# Altium schematic sheet RFB-D.SchDoc(RFB-D)
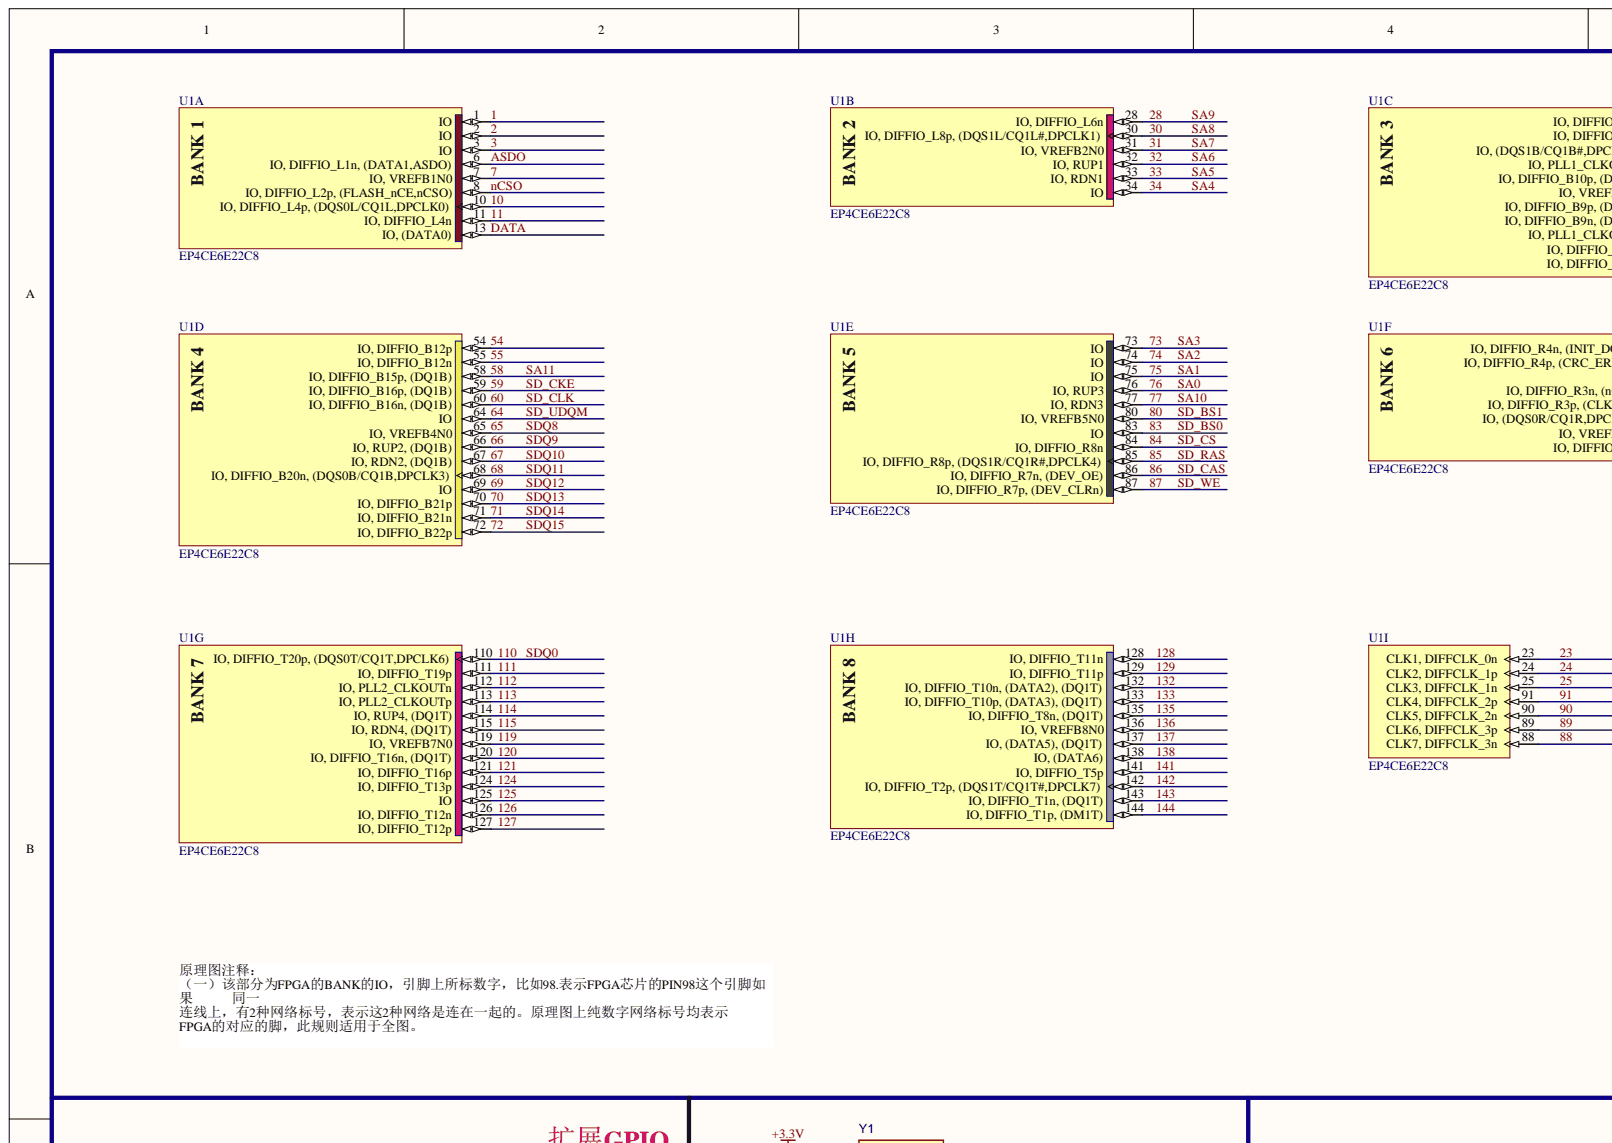
[diagram p0: RFB-D.SchDoc, top left]
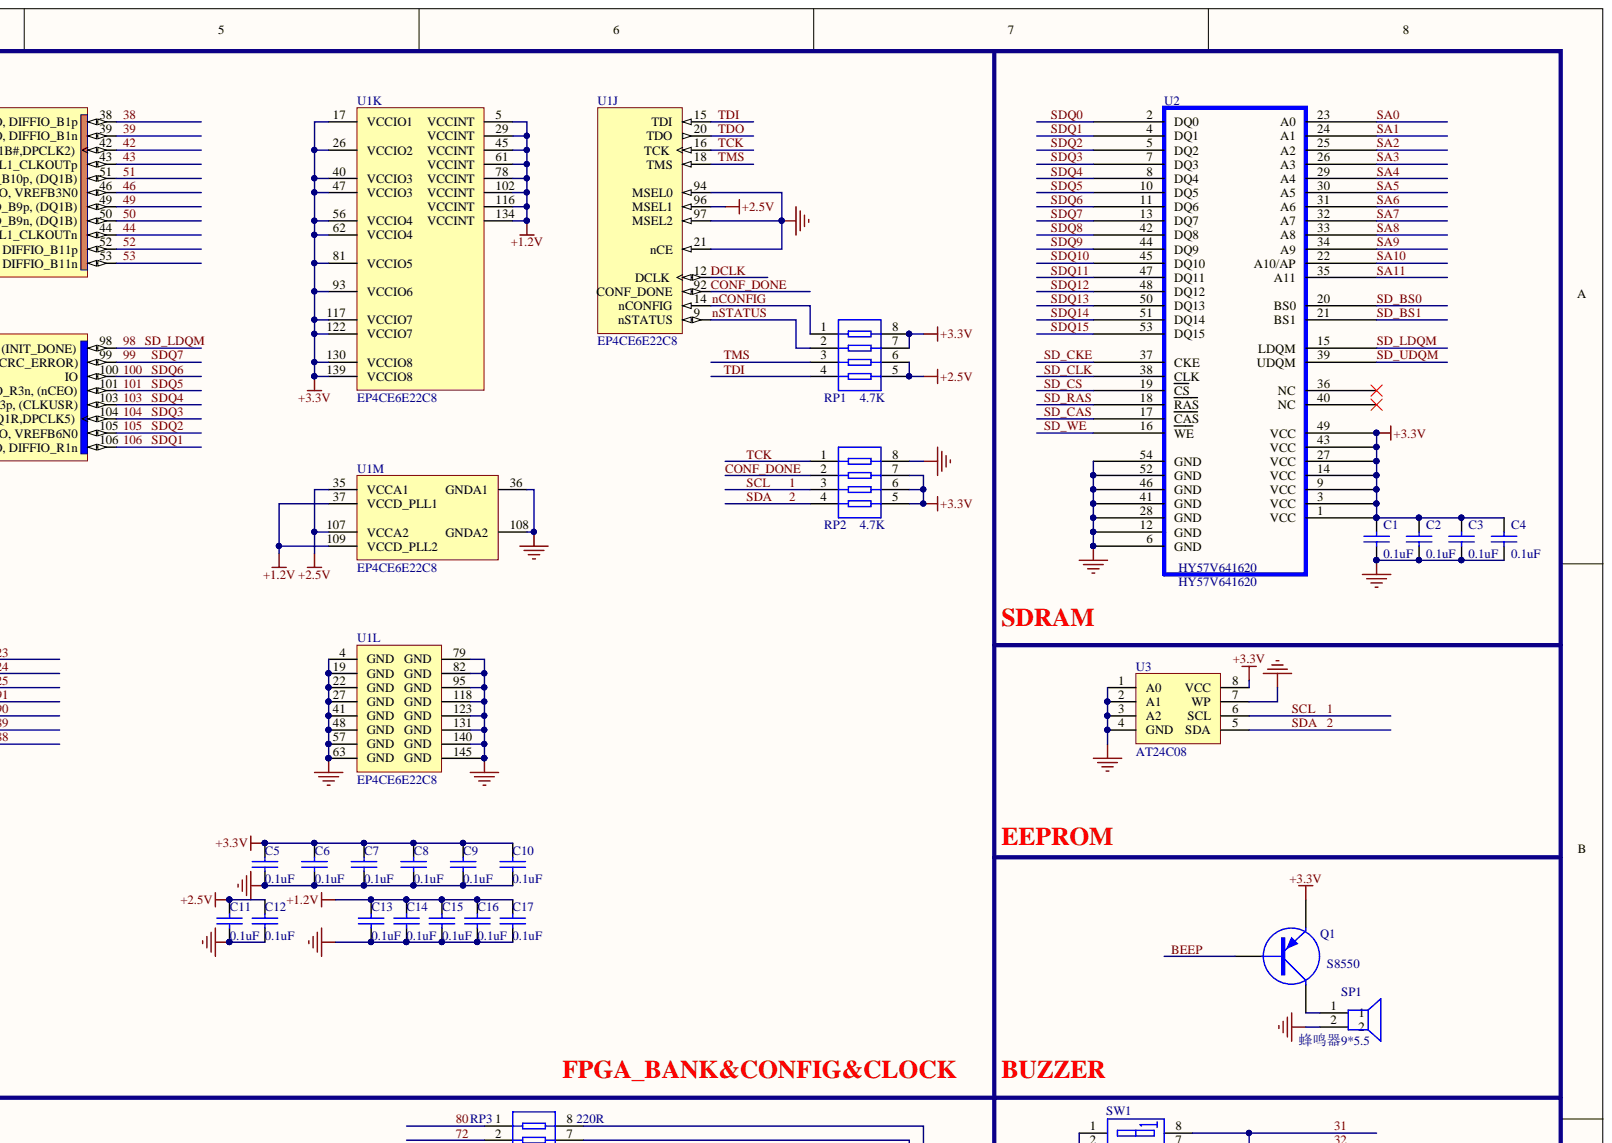
[diagram p1: RFB-D.SchDoc, top right]
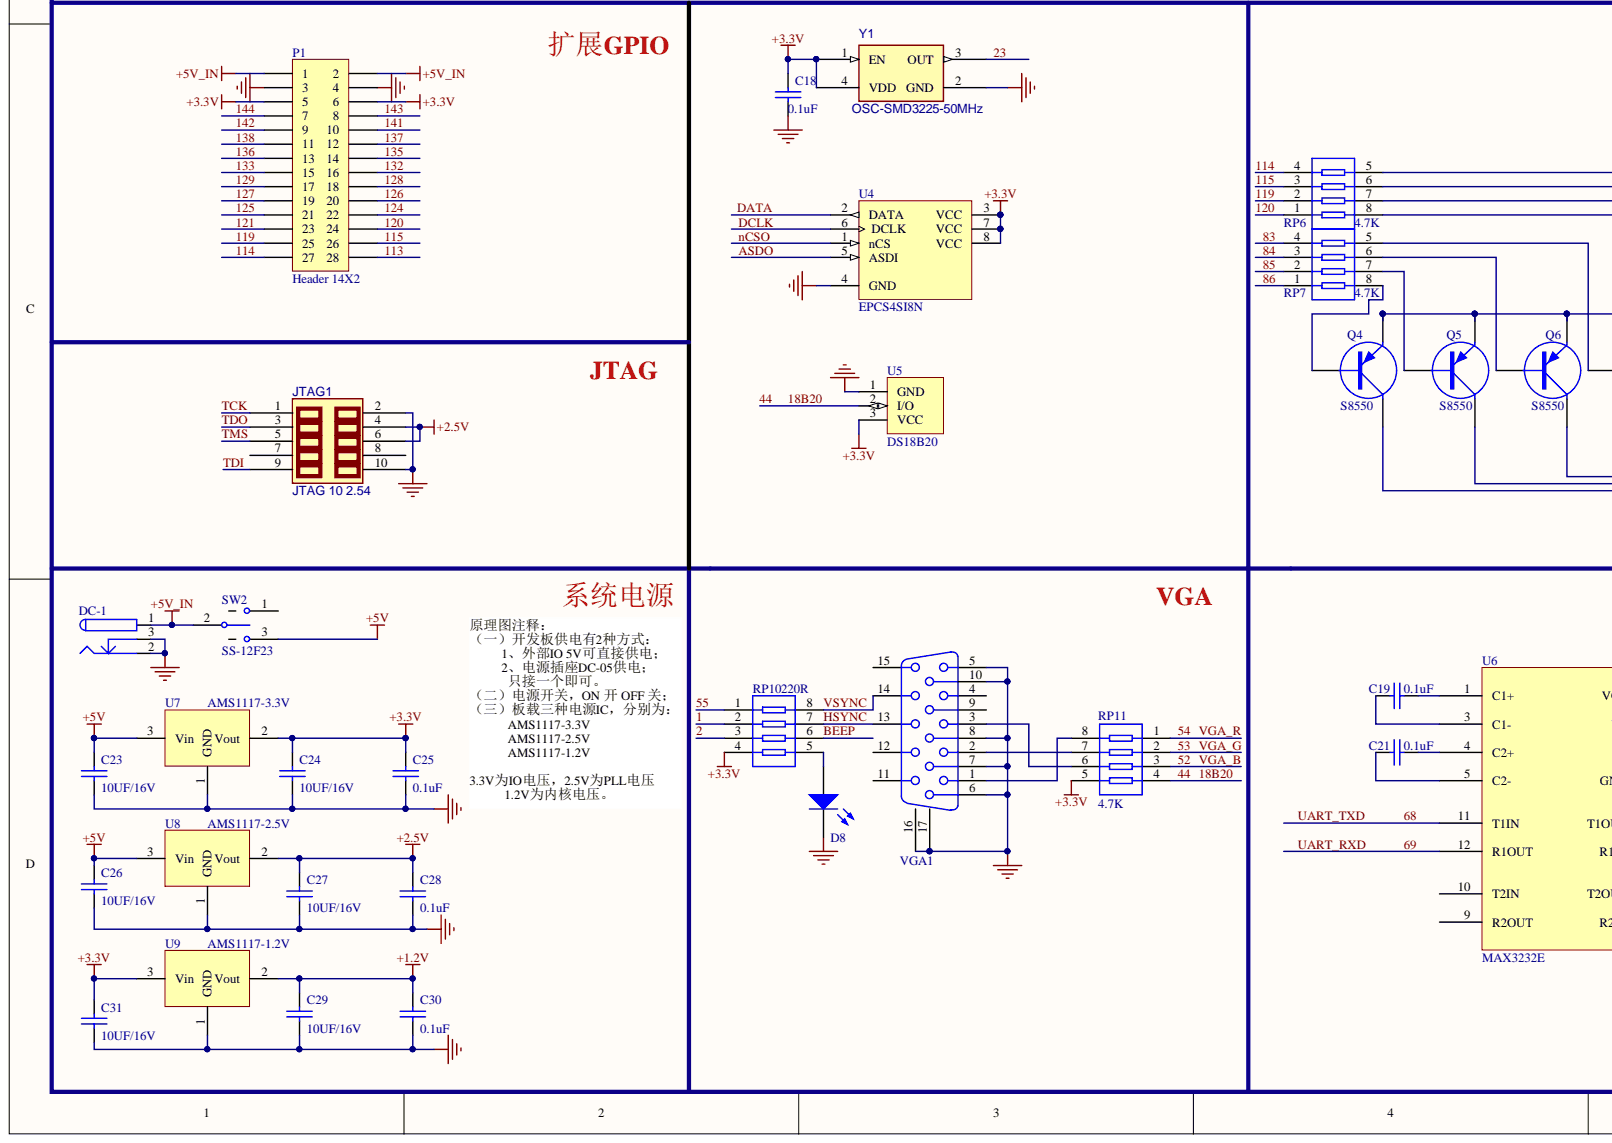
[diagram p2: RFB-D.SchDoc, bottom left]
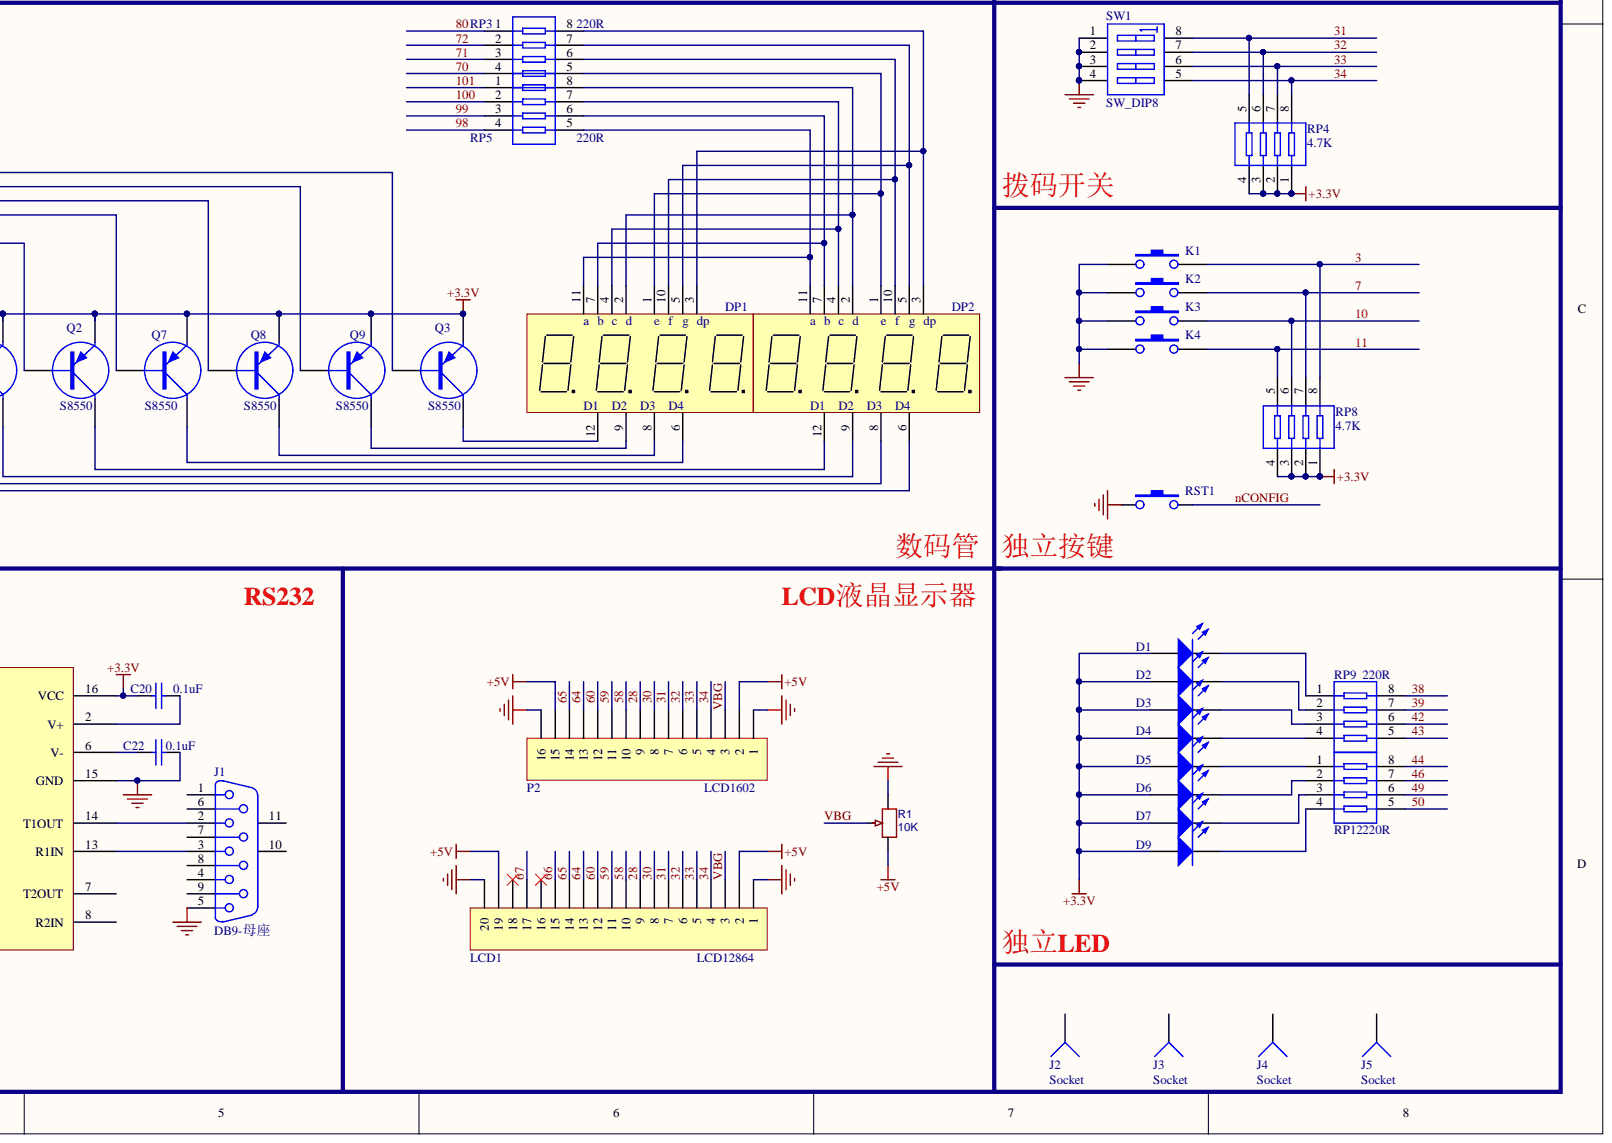
[diagram p3: RFB-D.SchDoc, bottom right]

## Parts
C1  0.1uF  [C0603(1608)]
C2  0.1uF  [C0603(1608)]
C3  0.1uF  [C0603(1608)]
C4  0.1uF  [C0603(1608)]
C5  0.1uF  [C0603(1608)]
C6  0.1uF  [C0603(1608)]
C7  0.1uF  [C0603(1608)]
C8  0.1uF  [C0603(1608)]
C9  0.1uF  [C0603(1608)]
C10  0.1uF  [C0603(1608)]
C11  0.1uF  [C0603(1608)]
C12  0.1uF  [C0603(1608)]
C13  0.1uF  [C0603(1608)]
C14  0.1uF  [C0603(1608)]
C15  0.1uF  [C0603(1608)]
C16  0.1uF  [C0603(1608)]
C17  0.1uF  [C0603(1608)]
C18  0.1uF  [C0603(1608)]
C19  0.1uF  [C0603(1608)]
C20  0.1uF  [C0603(1608)]
C21  0.1uF  [C0603(1608)]
C22  0.1uF  [C0603(1608)]
C23  10UF/16V  [C0805(2012)]
C24  10UF/16V  [C0805(2012)]
C25  0.1uF  [C0603(1608)]
C26  10UF/16V  [C0805(2012)]
C27  10UF/16V  [C0805(2012)]
C28  0.1uF  [C0603(1608)]
C29  10UF/16V  [C0805(2012)]
C30  0.1uF  [C0603(1608)]
C31  10UF/16V  [C0805(2012)]
D1  LED/BLUE  [LED0603(1608)]  (value not drawn)
D2  LED/BLUE  [LED0603(1608)]  (value not drawn)
D3  LED/BLUE  [LED0603(1608)]  (value not drawn)
D4  LED/BLUE  [LED0603(1608)]  (value not drawn)
D5  LED/BLUE  [LED0603(1608)]  (value not drawn)
D6  LED/BLUE  [LED0603(1608)]  (value not drawn)
D7  LED/BLUE  [LED0603(1608)]  (value not drawn)
D8  LED/RED  [LED0603(1608)]  (value not drawn)
D9  LED/BLUE  [LED0603(1608)]  (value not drawn)
DC-1  DC-005  [DC5.5*2.1]  (value not drawn)  (net tie)
DP1  4位共阴  [3.6_4LED]  (value not drawn)
DP2  4位共阴  [3.6_4LED]  (value not drawn)
J1  DB9-母座  [DB9-母座]
J2  Socket  [定位孔 Φ3-2]
J3  Socket  [定位孔 Φ3 -2]
J4  Socket  [定位孔 Φ3 -2]
J5  Socket  [定位孔 Φ3 -2]
JTAG1  JTAG 10 2.54  [JTAG-10-2.54]
K1  按键3*6  [KEY-6.0X3.3X4.3]  (value not drawn)
K2  按键3*6  [KEY-6.0X3.3X4.3]  (value not drawn)
K3  按键3*6  [KEY-6.0X3.3X4.3]  (value not drawn)
K4  按键3*6  [KEY-6.0X3.3X4.3]  (value not drawn)
LCD1  LCD12864  [HDR1X20]
P1  Header 14X2  [HDR2X14]
P2  LCD1602  [HDR1X16]
Q1  S8550  [SOT-23]
Q2  S8550  [SOT-23]
Q3  S8550  [SOT-23]
Q4  S8550  [SOT-23]
Q5  S8550  [SOT-23]
Q6  S8550  [SOT-23]
Q7  S8550  [SOT-23]
Q8  S8550  [SOT-23]
Q9  S8550  [SOT-23]
R1  10K  [RES-ADJ_3362P]
RP1  4.7K  [8P4R_0603]
RP2  4.7K  [8P4R_0603]
RP3  220R  [8P4R_0603]
RP4  4.7K  [8P4R_0603]
RP5  220R  [8P4R_0603]
RP6  4.7K  [8P4R_0603]
RP7  4.7K  [8P4R_0603]
RP8  4.7K  [8P4R_0603]
RP9  220R  [8P4R_0603]
RP10  220R  [8P4R_0603]
RP11  4.7K  [8P4R_0603]
RP12  220R  [8P4R_0603]
RST1  按键-直插  [KEY-6.0X3.3X4.3]  (value not drawn)
SP1  蜂鸣器9*5.5  [蜂鸣器9*5.5]
SW1  SW_DIP8  [DIP-8KEY]
SW2  SS-12F23  [SS-12F23]
U1  EP4CE6E22C8  [EQFP144_N]  (parts A,B,C,D,E,F,G,H,I,J,K,L,M)
U2  HY57V641620  [TSO-54]
U3  AT24C08  [SOIC-8]
U4  EPCS4SI8N  [SOIC-8]
U5  DS18B20  [DS18B20]
U6  MAX3232E  [SOJ-16]
U7  AMS1117-3.3V  [SOT-223]
U8  AMS1117-2.5V  [SOT-223]
U9  AMS1117-1.2V  [SOT-223]
VGA1  PRJ_OUT  [DB15FLC]  (value not drawn)
Y1  OSC-SMD3225-50MHz  [OSC-SMD3225]

## Nets
+1.2V: C13.1 C14.1 C15.1 C16.1 C17.1 C29.1 C30.1 U1.5(VCCINT) U1.29(VCCINT) U1.37(VCCD_PLL1) U1.45(VCCINT) U1.61(VCCINT) U1.78(VCCINT) U1.102(VCCINT) U1.109(VCCD_PLL2) U1.116(VCCINT) U1.134(VCCINT) U9.2
+2.5V: C11.1 C12.1 C27.1 C28.1 JTAG1.4 JTAG1.6 RP1.5 RP1.6 U1.35(VCCA1) U1.96(MSEL1) U1.107(VCCA2) U8.2
+3.3V: C1.2 C2.2 C3.2 C4.2 C5.1 C6.1 C7.1 C8.1 C9.1 C10.1 C18.1 C20.1 C24.1 C25.1 C31.1 D1.1 D2.1 D3.1 D4.1 D5.1 D6.1 D7.1 D9.1 P1.5 P1.6 Q1.2 Q2.2 Q3.2 Q4.2 Q5.2 Q6.2 Q7.2 Q8.2 Q9.2 RP1.7 RP1.8 RP2.5 RP2.6 RP2.7 RP4.1 RP4.2 RP4.3 RP4.4 RP8.1 RP8.2 RP8.3 RP8.4 RP10.4 RP11.5 U1.17(VCCIO1) U1.26(VCCIO2) U1.40(VCCIO3) U1.47(VCCIO3) U1.56(VCCIO4) U1.62(VCCIO4) U1.81(VCCIO5) U1.93(VCCIO6) U1.117(VCCIO7) U1.122(VCCIO7) U1.130(VCCIO8) U1.139(VCCIO8) U2.1(VCC) U2.3(VCC) U2.9(VCC) U2.14(VCC) U2.27(VCC) U2.43(VCC) U2.49(VCC) U3.8(VCC) U4.3(VCC) U4.7(VCC) U4.8(VCC) U5.3 U6.16(VCC) U7.2 U9.3 Y1.1(EN) Y1.4(VDD)
+5V: C23.1 C26.1 LCD1.2 LCD1.19 P2.2 P2.15 R1.3 SW2.3 U7.3 U8.3
+5V_IN: DC-1.1 P1.1 P1.2 SW2.2
GND: C1.1 C2.1 C3.1 C4.1 C5.2 C6.2 C7.2 C8.2 C9.2 C10.2 C11.2 C12.2 C13.2 C14.2 C15.2 C16.2 C17.2 C18.2 C22.2 C23.2 C24.2 C25.2 C26.2 C27.2 C28.2 C29.2 C30.2 C31.2 D8.2 DC-1.2 DC-1.3 J1.5 JTAG1.2 JTAG1.10 K1.1 K2.1 K3.1 K4.1 LCD1.1 LCD1.20 P1.3 P1.4 P2.1 P2.16 R1.1 RP2.8 RST1.1 SP1.2 SW1.1 SW1.2 SW1.3 SW1.4 U1.4(GND) U1.19(GND) U1.21(nCE) U1.22(GND) U1.27(GND) U1.36(GNDA1) U1.41(GND) U1.48(GND) U1.57(GND) U1.63(GND) U1.79(GND) U1.82(GND) U1.94(MSEL0) U1.95(GND) U1.97(MSEL2) U1.108(GNDA2) U1.118(GND) U1.123(GND) U1.131(GND) U1.140(GND) U1.145(GND) U2.6(GND) U2.12(GND) U2.28(GND) U2.41(GND) U2.46(GND) U2.52(GND) U2.54(GND) U3.1(A0) U3.2(A1) U3.3(A2) U3.4(GND) U3.7(WP) U4.4(GND) U5.1 U6.15(GND) U7.1 U8.1 U9.1 VGA1.5 VGA1.6 VGA1.7 VGA1.8 VGA1.10 VGA1.16 VGA1.17 Y1.2(GND)
1: RP2.3 RP10.2 U1.1(IO) U3.6(SCL)
2: RP2.4 RP10.3 U1.2(IO) U3.5(SDA)
3: K1.2 RP8.8 U1.3(IO)
7: K2.2 RP8.7 U1.7(IO,_VREFB1N0)
10: K3.2 RP8.6 U1.10(IO,_DIFFIO_L4p,_(DQS0L/CQ1L,DPCLK0))
11: K4.2 RP8.5 U1.11(IO,_DIFFIO_L4n)
18B20: RP11.4 RP12.8 U1.44(IO,_PLL1_CLKOUTn) U5.2
23: U1.23(CLK1,_DIFFCLK_0n) Y1.3(OUT)
24: U1.24(CLK2,_DIFFCLK_1p)
25: U1.25(CLK3,_DIFFCLK_1n)
28: LCD1.9 P2.9 U1.28(IO,_DIFFIO_L6n) U2.34(A9)
30: LCD1.8 P2.8 U1.30(IO,_DIFFIO_L8p,_(DQS1L/CQ1L#,DPCLK1)) U2.33(A8)
31: LCD1.7 P2.7 RP4.5 SW1.8 U1.31(IO,_VREFB2N0) U2.32(A7)
32: LCD1.6 P2.6 RP4.6 SW1.7 U1.32(IO,_RUP1) U2.31(A6)
33: LCD1.5 P2.5 RP4.7 SW1.6 U1.33(IO,_RDN1) U2.30(A5)
34: LCD1.4 P2.4 RP4.8 SW1.5 U1.34(IO) U2.29(A4)
38: RP9.8 U1.38(IO,_DIFFIO_B1p)
39: RP9.7 U1.39(IO,_DIFFIO_B1n)
42: RP9.6 U1.42(IO,_(DQS1B/CQ1B#,DPCLK2))
43: RP9.5 U1.43(IO,_PLL1_CLKOUTp)
46: RP12.7 U1.46(IO,_VREFB3N0)
49: RP12.6 U1.49(IO,_DIFFIO_B9p,_(DQ1B))
50: RP12.5 U1.50(IO,_DIFFIO_B9n,_(DQ1B))
51: U1.51(IO,_DIFFIO_B10p,_(DQ1B))
52: RP11.3 U1.52(IO,_DIFFIO_B11p)
53: RP11.2 U1.53(IO,_DIFFIO_B11n)
54: RP11.1 U1.54(IO,_DIFFIO_B12p)
55: RP10.1 U1.55(IO,_DIFFIO_B12n)
58: LCD1.10 P2.10 U1.58(IO,_DIFFIO_B15p,_(DQ1B)) U2.35(A11)
59: LCD1.11 P2.11 U1.59(IO,_DIFFIO_B16p,_(DQ1B)) U2.37(CKE)
60: LCD1.12 P2.12 U1.60(IO,_DIFFIO_B16n,_(DQ1B)) U2.38(CLK)
64: LCD1.13 P2.13 U1.64(IO) U2.39(UDQM)
65: LCD1.14 P2.14 U1.65(IO,_VREFB4N0) U2.42(DQ8)
66: LCD1.15 U1.66(IO,_RUP2,_(DQ1B)) U2.44(DQ9)
67: LCD1.17 U1.67(IO,_RDN2,_(DQ1B)) U2.45(DQ10)
68: U1.68(IO,_DIFFIO_B20n,_(DQS0B/CQ1B,DPCLK3)) U2.47(DQ11) U6.11(T1IN)
69: U1.69(IO) U2.48(DQ12) U6.12(R1OUT)
70: RP3.4 U1.70(IO,_DIFFIO_B21p) U2.50(DQ13)
71: RP3.3 U1.71(IO,_DIFFIO_B21n) U2.51(DQ14)
72: RP3.2 U1.72(IO,_DIFFIO_B22p) U2.53(DQ15)
73: U1.73(IO) U2.26(A3)
74: U1.74(IO) U2.25(A2)
75: U1.75(IO) U2.24(A1)
76: U1.76(IO,_RUP3) U2.23(A0)
77: U1.77(IO,_RDN3) U2.22(A10/AP)
80: RP3.1 U1.80(IO,_VREFB5N0) U2.21(BS1)
83: RP7.4 U1.83(IO) U2.20(BS0)
84: RP7.3 U1.84(IO,_DIFFIO_R8n) U2.19(CS)
85: RP7.2 U1.85(IO,_DIFFIO_R8p,_(DQS1R/CQ1R#,DPCLK4)) U2.18(RAS)
86: RP7.1 U1.86(IO,_DIFFIO_R7n,_(DEV_OE)) U2.17(CAS)
87: U1.87(IO,_DIFFIO_R7p,_(DEV_CLRn)) U2.16(WE)
88: U1.88(CLK7,_DIFFCLK_3n)
89: U1.89(CLK6,_DIFFCLK_3p)
90: U1.90(CLK5,_DIFFCLK_2n)
91: U1.91(CLK4,_DIFFCLK_2p)
98: RP5.4 U1.98(IO,_DIFFIO_R4n,_(INIT_DONE)) U2.15(LDQM)
99: RP5.3 U1.99(IO,_DIFFIO_R4p,_(CRC_ERROR)) U2.13(DQ7)
100: RP5.2 U1.100(IO) U2.11(DQ6)
101: RP5.1 U1.101(IO,_DIFFIO_R3n,_(nCEO)) U2.10(DQ5)
103: U1.103(IO,_DIFFIO_R3p,_(CLKUSR)) U2.8(DQ4)
104: U1.104(IO,_(DQS0R/CQ1R,DPCLK5)) U2.7(DQ3)
105: U1.105(IO,_VREFB6N0) U2.5(DQ2)
106: U1.106(IO,_DIFFIO_R1n) U2.4(DQ1)
110: U1.110(IO,_DIFFIO_T20p,_(DQS0T/CQ1T,DPCLK6)) U2.2(DQ0)
111: U1.111(IO,_DIFFIO_T19p)
112: U1.112(IO,_PLL2_CLKOUTn)
113: P1.28 U1.113(IO,_PLL2_CLKOUTp)
114: P1.27 RP6.4 U1.114(IO,_RUP4,_(DQ1T))
115: P1.26 RP6.3 U1.115(IO,_RDN4,_(DQ1T))
119: P1.25 RP6.2 U1.119(IO,_VREFB7N0)
120: P1.24 RP6.1 U1.120(IO,_DIFFIO_T16n,_(DQ1T))
121: P1.23 U1.121(IO,_DIFFIO_T16p)
124: P1.22 U1.124(IO,_DIFFIO_T13p)
125: P1.21 U1.125(IO)
126: P1.20 U1.126(IO,_DIFFIO_T12n)
127: P1.19 U1.127(IO,_DIFFIO_T12p)
128: P1.18 U1.128(IO,_DIFFIO_T11n)
129: P1.17 U1.129(IO,_DIFFIO_T11p)
132: P1.16 U1.132(IO,_DIFFIO_T10n,_(DATA2),_(DQ1T))
133: P1.15 U1.133(IO,_DIFFIO_T10p,_(DATA3),_(DQ1T))
135: P1.14 U1.135(IO,_DIFFIO_T8n,_(DQ1T))
136: P1.13 U1.136(IO,_VREFB8N0)
137: P1.12 U1.137(IO,_(DATA5),_(DQ1T))
138: P1.11 U1.138(IO,_(DATA6))
141: P1.10 U1.141(IO,_DIFFIO_T5p)
142: P1.9 U1.142(IO,_DIFFIO_T2p,_(DQS1T/CQ1T#,DPCLK7))
143: P1.8 U1.143(IO,_DIFFIO_T1n,_(DQ1T))
144: P1.7 U1.144(IO,_DIFFIO_T1p,_(DM1T))
ASDO: U1.6(IO,_DIFFIO_L1n,_(DATA1,ASDO)) U4.5(ASDI)
BEEP: Q1.1 RP10.6
CONF_DONE: RP2.2 U1.92(CONF_DONE)
DATA: U1.13(IO,_(DATA0)) U4.2(DATA)
DCLK: U1.12(DCLK) U4.6(DCLK)
HSYNC: RP10.7 VGA1.13
NCONFIG: RP1.1 RST1.2 U1.14(nCONFIG)
NCSO: U1.8(IO,_DIFFIO_L2p,_(FLASH_nCE,nCSO)) U4.1(nCS)
NSTATUS: RP1.2 U1.9(nSTATUS)
TCK: JTAG1.1 RP2.1 U1.16(TCK)
TDI: JTAG1.9 RP1.4 U1.15(TDI)
TDO: JTAG1.3 U1.20(TDO)
TMS: JTAG1.5 RP1.3 U1.18(TMS)
VBG: LCD1.3 P2.3 R1.2
VSYNC: RP10.8 VGA1.14
NetC19_1: C19.1 U6.3(C1-)
NetC19_2: C19.2 U6.1(C1+)
NetC20_2: C20.2 U6.2(V+)
NetC21_1: C21.1 U6.5(C2-)
NetC21_2: C21.2 U6.4(C2+)
NetC22_1: C22.1 U6.6(V-)
NetD1_2: D1.2 RP9.1
NetD2_2: D2.2 RP9.2
NetD3_2: D3.2 RP9.3
NetD4_2: D4.2 RP9.4
NetD5_2: D5.2 RP12.1
NetD6_2: D6.2 RP12.2
NetD7_2: D7.2 RP12.3
NetD8_1: D8.1 RP10.5
NetD9_2: D9.2 RP12.4
NetDP1_1: DP1.1(e) DP2.1 RP3.5
NetDP1_2: DP1.2 DP2.2 RP5.8
NetDP1_3: DP1.3 DP2.3 RP3.8
NetDP1_4: DP1.4(c) DP2.4(c) RP5.7
NetDP1_5: DP1.5 DP2.5 RP3.7
NetDP1_6: DP1.6(D4) Q7.3
NetDP1_7: DP1.7(b) DP2.7 RP5.6
NetDP1_8: DP1.8 Q8.3
NetDP1_9: DP1.9(D2) Q9.3
NetDP1_10: DP1.10 DP2.10(f) RP3.6
NetDP1_11: DP1.11 DP2.11 RP5.5
NetDP1_12: DP1.12(D1) Q3.3
NetDP2_6: DP2.6(D4) Q4.3
NetDP2_8: DP2.8(D3) Q5.3
NetDP2_9: DP2.9(D2) Q6.3
NetDP2_12: DP2.12(D1) Q2.3
NetJ1_2: J1.2 U6.14(T1OUT)
NetJ1_3: J1.3 U6.13(R1IN)
NetQ1_3: Q1.3 SP1.1
NetQ2_1: Q2.1 RP7.5
NetQ3_1: Q3.1 RP6.5
NetQ4_1: Q4.1 RP7.8
NetQ5_1: Q5.1 RP7.7
NetQ6_1: Q6.1 RP7.6
NetQ7_1: Q7.1 RP6.8
NetQ8_1: Q8.1 RP6.7
NetQ9_1: Q9.1 RP6.6
NetRP11_6: RP11.6 VGA1.3
NetRP11_7: RP11.7 VGA1.2
NetRP11_8: RP11.8 VGA1.1

Not connected: J1 1 4 6 7 8 9 10 11; J2 1; J3 1; J4 1; J5 1; JTAG1 7 8; LCD1 16 18; SW2 1 5 6; U2 36(NC) 40(NC); U6 7(T2OUT) 8(R2IN) 9(R2OUT) 10(T2IN); VGA1 4 9 11 12 15

## Library data of the parts
C1-C31 = CAP-SMT: Component Kind: Standard
  C1-C4 C18 C23-C31: Library Name: Miscellaneous Devices.IntLib
  C5-C17 C19-C22: Library Name: XARP-FA01.SchLib
D1-D9 = LED_0603: Typical RED, GREEN, YELLOW, AMBER GaAs LED; Component Kind: Standard; LatestRevisionDate: 17-Jul-2002; LatestRevisionNote: Re-released for DXP Platform.; Library Name: Miscellaneous Devices.IntLib; PackageDescription: SM LED; 2 Flat Leads; PackageReference: 3.2X1.6X1.1; PackageVersion: Feb-2002; Published: 8-Jun-2000; Publisher: Altium Limited
DC-1 = PWR2.5: Low Voltage Power Supply Connector; ComponentLink1Description: Manufacturer Link; ComponentLink1URL: http://domino.kycon.com/internet/Products.html; DatasheetDocument: 09-May-2002; Published: 17-Dec-2003; Signal Integrity: Connector; Library Name: XARP-FA01.SchLib; Publisher: Altium Limited
DP1 DP2 = DPY4: Component Kind: Standard; Library Name: XARP-FA01.SchLib
J1 = DB9: Receptacle Assembly, 9 Position, Right Angle; PackageDocument: O; Positions: 9; Component Kind: Standard; DatasheetDocument: 1-Sep-1999; Gender: Receptacle; LatestRevisionDate: 17-Jul-2002; LatestRevisionNote: Re-released for DXP Platform.; Library Name: Miscellaneous Connectors.SchLib; Orientation: Right Angle; PackageReference: 788750; Published: 15-Jun-2001; Signal Integrity: Connector; Publisher: Altium Limited
JTAG1 = JTAG-10-2.54: Component Kind: Standard; Library Name: XARP-FA01.SchLib
K1-K4 = SW-PB: Component Kind: Standard; Library Name: XARP-FA01.SchLib
LCD1 = Header 20: Header, 20-Pin; Component Kind: Standard; LatestRevisionDate: 17-Jul-2002; LatestRevisionNote: Re-released for DXP Platform.; Signal Integrity: Connector; Library Name: XARP-FA01.SchLib; Publisher: Altium Limited
P1 = Header 14X2: Header, 14-Pin, Dual row; Component Kind: Standard; LatestRevisionDate: 17-Jul-2002; LatestRevisionNote: Re-released for DXP Platform.; Library Name: Miscellaneous Connectors.SchLib; Signal Integrity: Connector; Publisher: Altium Limited
P2 = Header 16: Header, 16-Pin; Component Kind: Standard; LatestRevisionDate: 17-Jul-2002; LatestRevisionNote: Re-released for DXP Platform.; Library Name: Miscellaneous Connectors.SchLib; Signal Integrity: Connector; Publisher: Altium Limited
Q1-Q9 = PNP: PNP Transistor; Component Kind: Standard; Library Name: XARP-FA01.SchLib
R1 = 5K: BOM_Manufacturer: BOURNS; BOM_Manufacturer Part: 3362P-1-502LF; nameAlias: Resistance(Ohms); BOM_Supplier: LCSC; BOM_Supplier Part: C81260; Component Kind: Standard; spicePre: R; spiceSymbolName: 3362P-1-502LF
RP1-RP12 = 8P4R_0603: Component Kind: Standard; Library Name: Miscellaneous Devices.SchLib
RST1 = SW: Component Kind: Standard; Library Name: Miscellaneous Connectors.SchLib
SP1 = SPEAKER: Component Kind: Standard; Library Name: XARP-FA01.SchLib
SW1 = SW_DIP8: DIP Switch; Component Kind: Standard; Library Name: Miscellaneous Connectors.SchLib
SW2 = SS-12F23: Double-Pole, Double-Throw Switch; Component Kind: Standard; LatestRevisionDate: 17-Jul-2002; LatestRevisionNote: Re-released for DXP Platform.; PackageDescription: Switch; 6 Leads; PackageReference: DPDT-6; Published: 24-Mar-1999; Library Name: XARP-FA01.SchLib; Publisher: Altium Limited
U1 = EP4CE6E22C8: Cyclone IV Family FPGA, 2V Core, 91 I/O Pins, 2 PLLs, 144-Pin QFP, Speed Grade 8, Commercial Grade; Code_JEDEC: MS-026-BFB; Component Kind: Standard; ComponentLink1Description: Manufacturer Link; ComponentLink1URL: http://www.altera.com/; ComponentLink2Description: Handbook; ComponentLink2URL: http://www.altera.com/literature/hb/cyclone-iv/cyclone4-handbook.pdf; ComponentLink3Description: Pinout Specification; ComponentLink3URL: http://www.altera.com/literature/lit-dp.jsp?category=cyc%204&showspreadsheet=y; ComponentLink4Description: Packaging Specification; ComponentLink4URL: http://www.altera.com/literature/ds/dspkg.pdf; DatasheetVersion: ver1.2, Mar-2010; Library Name: Miscellaneous Devices.SchLib; PackageDescription: 144-Pin Plastic Enhanced Quad Flat Pack (EQFP144) - Wire Bond; PackageReference: EQFP144; PackageVersion: ver16.0, Dec-2009; Published: 3-May-2010; Publisher: Altium Limited
U4 = EPCS16SI8: Component Kind: Standard; Library Name: XARP-FA01.SchLib
U6 = SP3232E: Component Kind: Standard; Library Name: XARP-FA01.SchLib
U7-U9 = 1117: Component Kind: Standard; Library Name: XARP-FA01.SchLib
VGA1 = DB15: Component Kind: Standard; Library Name: XARP-FA01.SchLib
J2-J5 = Socket: Component Kind: Standard; Description: Socket; LatestRevisionDate: 17-Jul-2002; LatestRevisionNote: Re-released for DXP Platform.; Library Name: Miscellaneous Connectors.IntLib; Publisher: Altium Limited
U2 = HY57V641620: Component Kind: Standard; Description: HY57V641620
U3 = AT24C08: Component Kind: Standard; Library Name: XARP-FA01.SchLib
U5 = DS18B20: Component Kind: Standard; Library Name: Miscellaneous Devices.SchLib
Y1 = OSC-SMD3225-50MHz: Component Kind: Standard; Library Name: XARP-FA01.SchLib
